# Altium schematic sheet NUC240.SchDoc(NUC240)
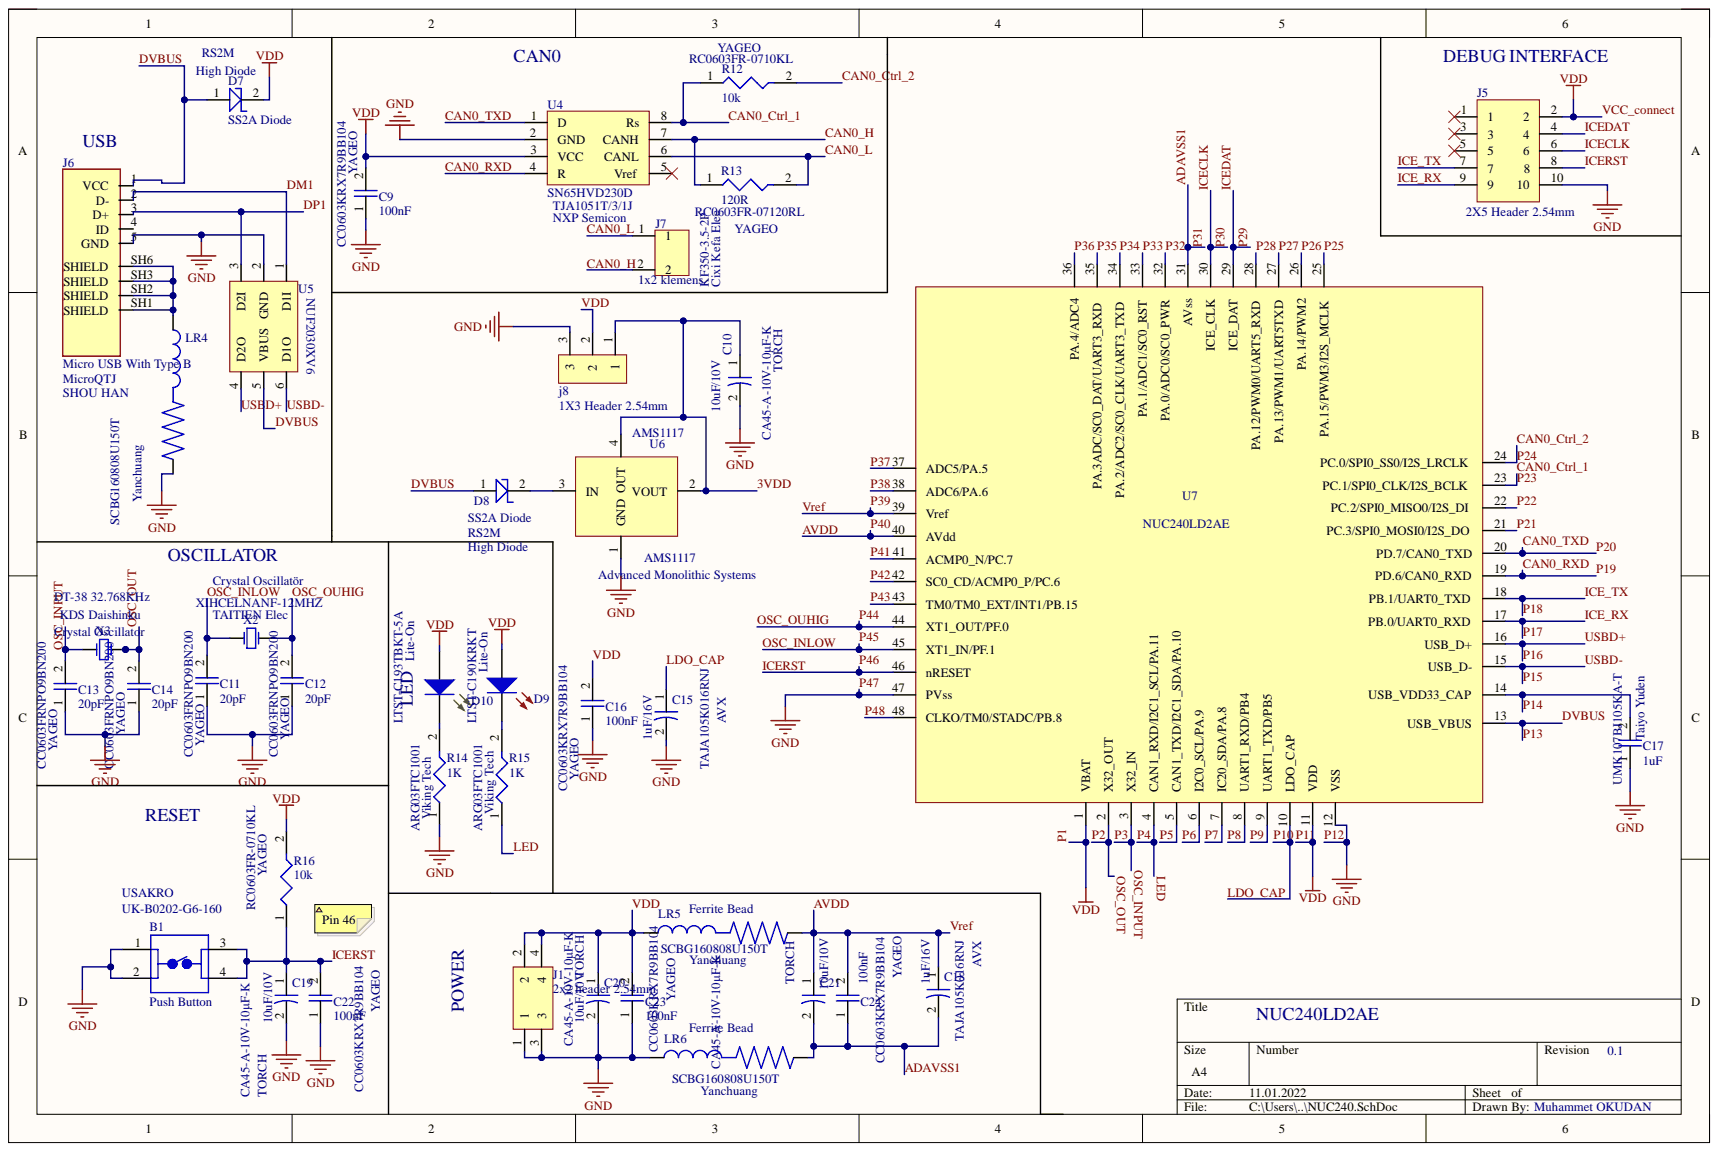
[diagram p0: NUC240.SchDoc]

## Parts
B1  Push Button  *Mfg Part#: UK-B0202-G6-160  [6x6 push button]
C9  100nF  *Mfg Part#: CC0603KRX7R9BB104  Manufacturer: YAGEO  [CAP 0603]
C10  10uF/10V  *Mfg Part#: CA45-A-10V-10μF-K  Manufacturer: TORCH  [T491A104K035AT TANTAL CAP]
C11  20pF  *Mfg Part#: CC0603FRNPO9BN200  Manufacturer: YAGEO  [CAP 0603]
C12  20pF  *Mfg Part#: CC0603FRNPO9BN200  Manufacturer: YAGEO  [CAP 0603]
C13  20pF  *Mfg Part#: CC0603FRNPO9BN200  Manufacturer: YAGEO  [CAP 0603]
C14  20pF  Manufacturer: YAGEO  [CAP 0603]
C15  1uF/16V  *Mfg Part#: TAJA105K016RNJ  [T491A104K035AT TANTAL CAP]
C16  100nF  *Mfg Part#: CC0603KRX7R9BB104  Manufacturer: YAGEO  [CAP 0603]
C17  1uF  *Mfg Part#: UMK107BJ105KA-T  Manufacturer: Taiyo Yuden  [CAP 0603]
C18  1uF/16V  *Mfg Part#: TAJA105K016RNJ  Manufacturer: AVX  [T491A104K035AT TANTAL CAP]
C19  10uF/10V  [T491A104K035AT TANTAL CAP]
C20  10uF/10V  Manufacturer: TORCH  [T491A104K035AT TANTAL CAP]
C21  10uF/10V  Manufacturer: TORCH  [T491A104K035AT TANTAL CAP]
C22  100nF  *Mfg Part#: CC0603KRX7R9BB104  [CAP 0603]
C23  100nF  *Mfg Part#: CC0603KRX7R9BB104  Manufacturer: YAGEO  [CAP 0603]
C24  100nF  *Mfg Part#: CC0603KRX7R9BB104  [CAP 0603]
D7  SS2A Diode  Manufacturer: High Diode  [Diode SS2A]
D8  SS2A Diode  *Mfg Part#: RS2M  [Diode SS2A]
D9  Manufacturer: Lite-On  [LED Red]  (hidden comment: Led Red)
D10  *Mfg Part#: LTST-C193TBKT-5A  Manufacturer: Lite-On  [LED Green]  (hidden comment: LED Green)
J1  2x2 header 2.54mm  [2X2 HEADER 2.54MM]
J5  2X5 Header 2.54mm  [2x5 header 2.54mm]
J6  Micro USB With Type B  *Mfg Part#: MicroQTJ  [Micro USB with type B]
J7  1x2 klemens  *Mfg Part#: KF350-3.5-2P  [1x2 Klemens]
j8  1X3 Header 2.54mm  [1x3 2.54mm]
LR4  Ferrite Bead  Manufacturer: Yanchuang  [FERRITE BEAD 0603]
LR5  Ferrite Bead  *Mfg Part#: SCBG160808U150T  Manufacturer: Yanchuang  [FERRITE BEAD 0603]
LR6  Ferrite Bead  *Mfg Part#: SCBG160808U150T  Manufacturer: Yanchuang  [FERRITE BEAD 0603]
R12  10k  *Mfg Part#: RC0603FR-0710KL  Manufactuer: YAGEO  [0603 RES]
R13  120R  *Mfg Part#: RC0603FR-07120RL  [0603 RES]
R14  1K  *Mfg Part#: ARG03FTC1001  Manufacturer: Viking Tech  [0603 RES]
R15  1K  *Mfg Part#: ARG03FTC1001  Manufacturer: Viking Tech  [0603 RES]
R16  10k  *Mfg Part#: RC0603FR-0710KL  Manufacturer: YAGEO  [0603 RES]
U4  SN65HVD230D  *Mfg Part#: TJA1051T/3/1J  [SN65HVD231D]
U5  NUF2030XV6  [NUF2030XV6]
U6  AMS1117  Manufacturer: Advanced Monolithic Systems  [SOT223]
U7  NUC240LD2AE  [LQFP50P900X900X160-48N]
X2  Crystal Oscillatör  *Mfg Part#: XIHCELNANF-12MHZ  Manufacturer: TAITIEN Elec  [Crystal Oscillatör]
X3  Crystal Oscillator  Manufacturer: KDS Daishinku  [32.768 KHz Oscillatör]

## Nets
3VDD: C10.1 j8.1 U6.2(VOUT) U6.4(OUT)
ADAVSS1: C18.2 C21.2 C24.1 LR6.2 U7.31(AVss)
AVDD: C18.1 C21.1 C24.2 LR5.2 U7.39(Vref) U7.40(AVdd)
CAN0_Ctrl_1: R12.1 U4.8(Rs) U7.23(PC.1/SPI0_CLK/I2S_BCLK)
CAN0_Ctrl_2: R12.2 U7.24(PC.0/SPI0_SS0/I2S_LRCLK)
CAN0_H: J7.2 R13.1 U4.7(CANH)
CAN0_L: J7.1 R13.2 U4.6(CANL)
CAN0_RXD: U4.4(R) U7.19(PD.6/CAN0_RXD)
CAN0_TXD: U4.1(D) U7.20(PD.7/CAN0_TXD)
DM1: J6.2(D-) U5.1(D1I)
DP1: J6.3(D+) U5.3(D2I)
DVBUS: D7.1 D8.1 J6.1(VCC) U5.5(VBUS) U7.13(USB_VBUS)
LDO_CAP: C15.1 U7.10(LDO_CAP)
LED: R15.1 U7.4(CAN1_RXD/I2C1_SCL/PA.11)
OSC_INLOW: C11.2 U7.45(XT1_IN/PF.1) X2.2
OSC_INPUT: C13.2 U7.3(X32_IN) X3.1
OSC_OUHIG: C12.2 U7.44(XT1_OUT/PF.0) X2.1
OSC_OUT: C14.2 U7.2(X32_OUT) X3.2
P1: C9.2 C16.2 C20.1 C23.2 D7.2 D9.1 D10.1 J1.2 J1.4 J5.2 j8.2 LR5.1 R16.2 U4.3(VCC) U7.1(VBAT) U7.11(VDD)
P5: U7.5(CAN1_TXD/I2C1_SDA/PA.10)
P6: U7.6(I2C0_SCL/PA.9)
P7: U7.7(IC20_SDA/PA.8)
P8: U7.8(UART1_RXD/PB4)
P9: U7.9(UART1_TXD/PB5)
P12: B1.1 B1.2 C9.1 C10.2 C11.1 C12.1 C13.1 C14.1 C15.2 C16.1 C17.1 C19.2 C20.2 C22.1 C23.1 J1.1 J1.3 J5.10 J6.5(GND) j8.3 LR4.2 LR6.1 R14.1 U4.2(GND) U5.2(GND) U6.1(GND) U7.12(VSS) U7.47(PVss)
P14: C17.2 U7.14(USB_VDD33_CAP)
P15: U5.6(D1O) U7.15(USB_D-)
P16: U5.4(D2O) U7.16(USB_D+)
P17: J5.9 U7.17(PB.0/UART0_RXD)
P18: J5.7 U7.18(PB.1/UART0_TXD)
P21: U7.21(PC.3/SPI0_MOSI0/I2S_DO)
P22: U7.22(PC.2/SPI0_MISO0/I2S_DI)
P25: U7.25(PA.15/PWM3/I2S_MCLK)
P26: U7.26(PA.14/PWM2)
P27: U7.27(PA.13/PWM1/UART5TXD)
P28: U7.28(PA.12/PWM0/UART5_RXD)
P29: J5.4 U7.29(ICE_DAT)
P30: J5.6 U7.30(ICE_CLK)
P32: U7.32(PA.0/ADC0/SC0_PWR)
P33: U7.33(PA.1/ADC1/SC0_RST)
P34: U7.34(PA.2/ADC2/SC0_CLK/UART3_TXD)
P35: U7.35(PA.3ADC/SC0_DAT/UART3_RXD)
P36: U7.36(PA.4/ADC4)
P37: U7.37(ADC5/PA.5)
P38: U7.38(ADC6/PA.6)
P41: U7.41(ACMP0_N/PC.7)
P42: U7.42(SC0_CD/ACMP0_P/PC.6)
P43: U7.43(TM0/TM0_EXT/INT1/PB.15)
P46: B1.3 B1.4 C19.1 C22.2 J5.8 R16.1 U7.46(nRESET)
P48: U7.48(CLKO/TM0/STADC/PB.8)
NetD8_2: D8.2 U6.3(IN)
NetD9_2: D9.2 R15.2
NetD10_2: D10.2 R14.2
NetJ6_SH1: J6.SH1(SHIELD) J6.SH2(SHIELD) J6.SH3(SHIELD) J6.SH6(SHIELD) LR4.1

Not connected: J5 1 3 5; J6 4(ID); U4 5(Vref)

## Library data of the parts
B1 = Push Button: Manufacturer: USAKRO; Component Kind: Standard; Library Name: NUV.SchLib
C9 C11-C13 C16 C17 C23 = 0603 CAP: Component Kind: Standard; Library Name: NUV.SchLib
C10 C18 = 0603 TANTAL CAP: TANTAL CAP 0603; Component Kind: Standard; Library Name: NUV.SchLib
C14 = 0603 CAP: *Mfg Part#: CC0603FRNPO9BN200; Component Kind: Standard; Library Name: NUV.SchLib
C15 = 0603 TANTAL CAP: TANTAL CAP 0603; Manufacturer: AVX; Component Kind: Standard; Library Name: NUV.SchLib
C19 = 0603 TANTAL CAP: TANTAL CAP 0603; *Mfg Part#: CA45-A-10V-10μF-K; Manufacturer: TORCH; Component Kind: Standard; Library Name: NUV.SchLib
C20 C21 = 0603 TANTAL CAP: TANTAL CAP 0603; *Mfg Part#: CA45-A-10V-10μF-K; Component Kind: Standard; Library Name: NUV.SchLib
C22 C24 = 0603 CAP: Manufacturer: YAGEO; Component Kind: Standard; Library Name: NUV.SchLib
D7 = SS2A Diode: *Mfg Part#: RS2M; Component Kind: Standard; Library Name: NUV.SchLib
D8 = SS2A Diode: Manufacturer: High Diode; Component Kind: Standard; Library Name: NUV.SchLib
D9 = Led Red: *Mfg Part#: LTST-C190KRKT; Component Kind: Standard; Description: led red; Library Name: NUV.SchLib
J6 = Micro USB With Type B: Manufacturer: SHOU HAN; Component Kind: Standard; Library Name: NUV.SchLib
J7 = 1x2 klemens: Manufacturer: Cixi Kefa Elec; Component Kind: Standard; Library Name: NUV.SchLib
LR4 = Ferrite Bead: 0603 FERRITE BEAD; *Mfg Part#: SCBG160808U150T; Component Kind: Standard; Library Name: NUV.SchLib
LR5 LR6 = Ferrite Bead: 0603 FERRITE BEAD; Component Kind: Standard; Library Name: NUV.SchLib
R12 R14-R16 = 0603 RES: Component Kind: Standard; Library Name: NUV.SchLib
R13 = 0603 RES: Manufacturer: YAGEO; Component Kind: Standard; Library Name: NUV.SchLib
U4 = SN65HVD230D: CAN TRANSCEIVER; Manufacturer: NXP Semicon; Component Kind: Standard; Library Name: NUV.SchLib
U7 = NUC240LD2AE: Integrated Circuit; Component Kind: Standard; Library Name: NUV.SchLib
X2 = Crystal Oscillatör: 12Mhz; Component Kind: Standard; Library Name: NUV.SchLib
X3 = Crystal Oscillator: 32.768KHz; *Mfg Part#: DT-38 32.768KHz; Component Kind: Standard; Library Name: NUV.SchLib
D10 = LED Green: Component Kind: Standard; Description: Led Green; Library Name: NUV.SchLib
J1 = 2x2 header 2.54mm: Component Kind: Standard; Library Name: NUV.SchLib
J5 = 2X5 Header 2.54mm: Component Kind: Standard; Library Name: NUV.SchLib
j8 = 1X3 Header 2.54mm: Component Kind: Standard; Library Name: NUV.SchLib
U5 = NUF2030XV6: Component Kind: Standard; Library Name: NUV.SchLib
U6 = AMS1117: *Mfg Part#: AMS1117; Component Kind: Standard; Library Name: NUV.SchLib
